# Altium schematic sheet PCIe Slots.SchDoc
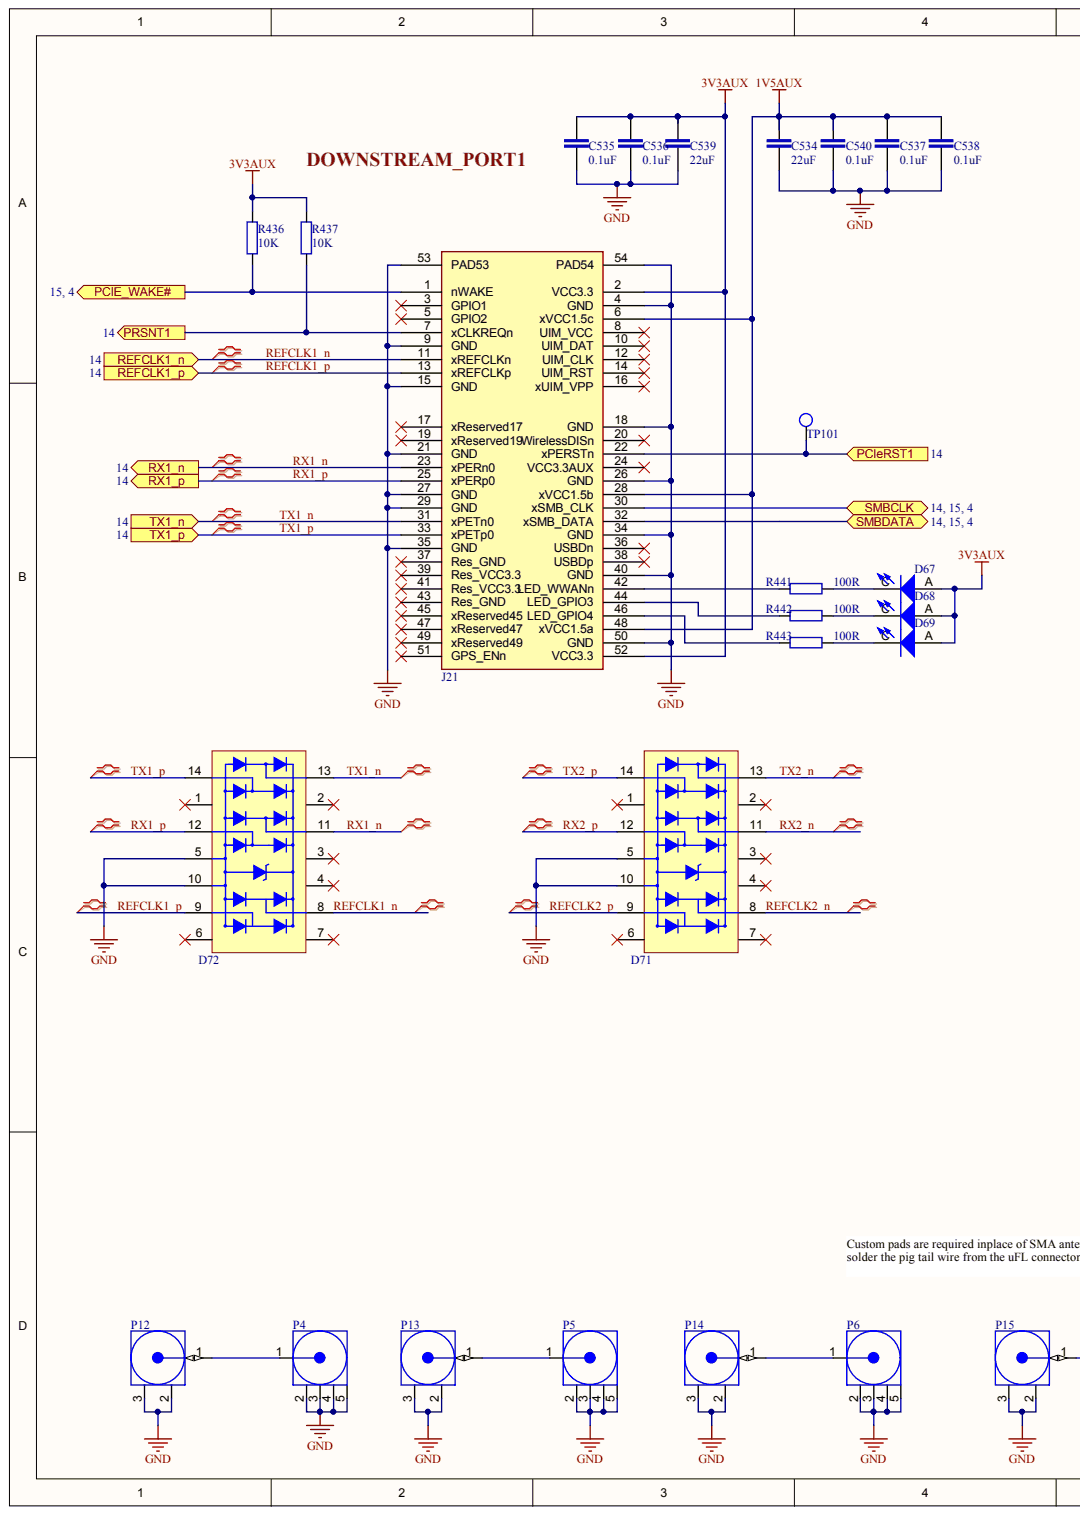
[diagram p0: PCIe Slots.SchDoc, left]
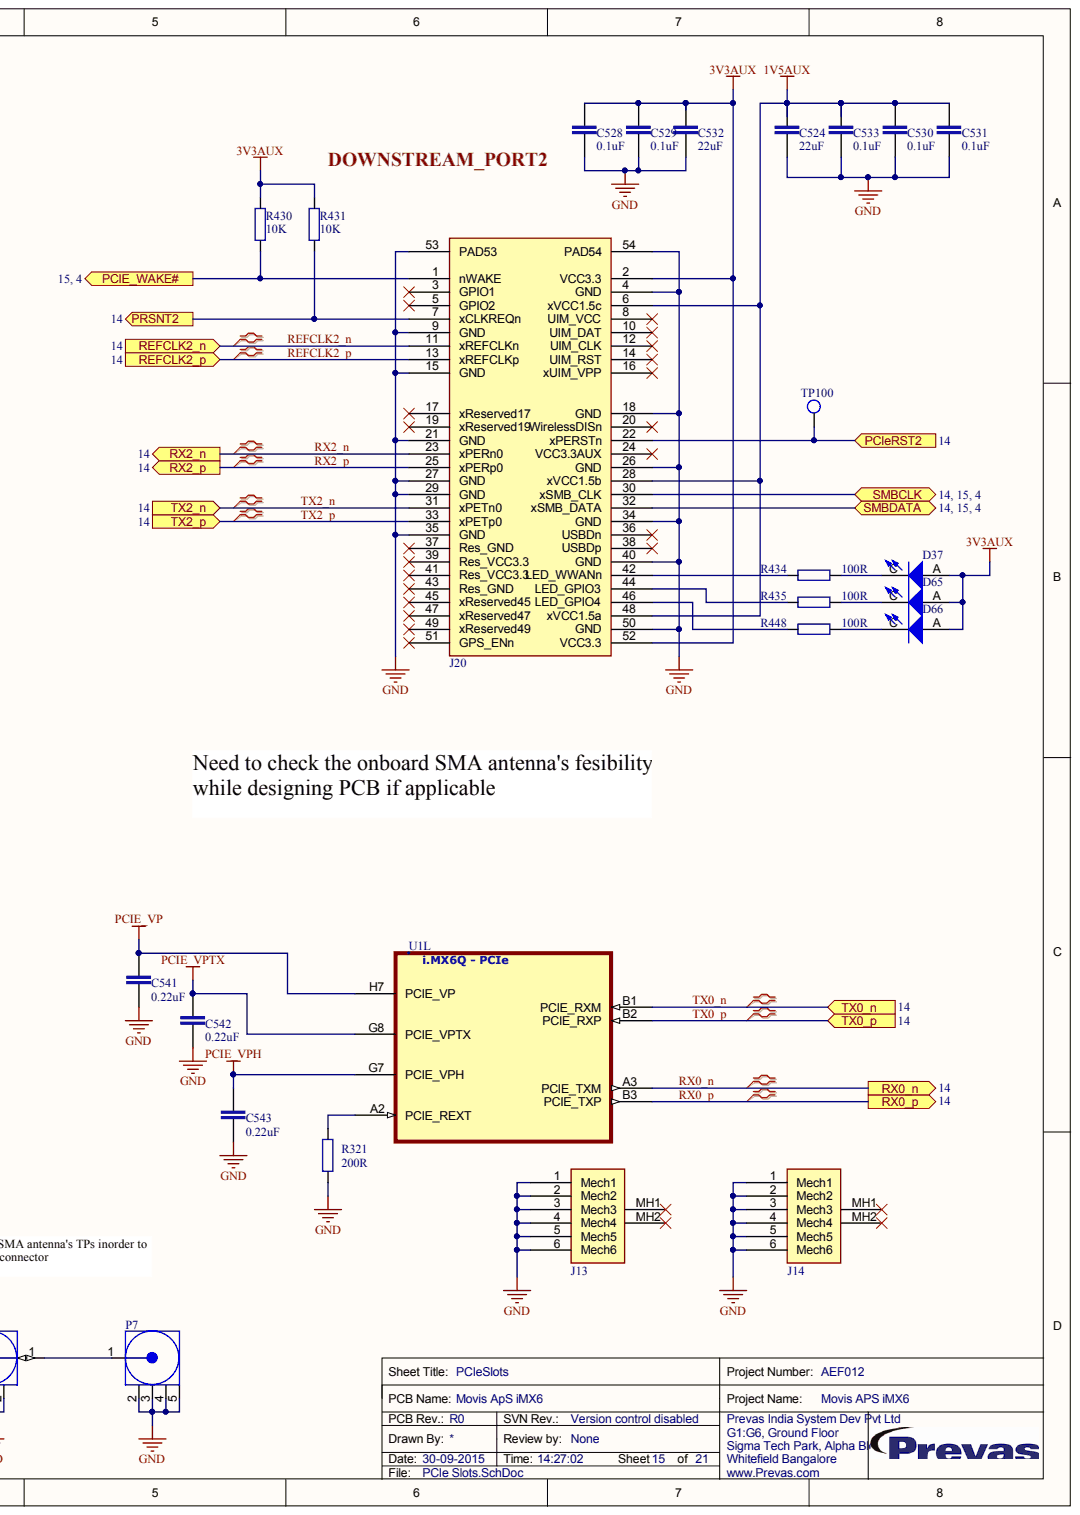
[diagram p1: PCIe Slots.SchDoc, right]

## Parts
C524  22uF  [CAPC3216X180N]
C528  0.1uF  [CAPC1005X55N]
C529  0.1uF  [CAPC1005X55N]
C530  0.1uF  [CAPC1005X55N]
C531  0.1uF  [CAPC1005X55N]
C532  22uF  [CAPC3216X180N]
C533  0.1uF  [CAPC1005X55N]
C534  22uF  [CAPC3216X180N]
C535  0.1uF  [CAPC1005X55N]
C536  0.1uF  [CAPC1005X55N]
C537  0.1uF  [CAPC1005X55N]
C538  0.1uF  [CAPC1005X55N]
C539  22uF  [CAPC3216X180N]
C540  0.1uF  [CAPC1005X55N]
C541  0.22uF  [CAPC1005X55N]
C542  0.22uF  [CAPC1005X55N]
C543  0.22uF  [CAPC1005X55N]
D37  elec_value  [LED_LTT64G]  (value not drawn)
D65  elec_value  [LED_LTT64G]  (value not drawn)
D66  elec_value  [LED_LTT64G]  (value not drawn)
D67  elec_value  [LED_LTT64G]  (value not drawn)
D68  elec_value  [LED_LTT64G]  (value not drawn)
D69  elec_value  [LED_LTT64G]  (value not drawn)
D71  TPD6E05U06  [SON50P135X350X55-14N]  (value not drawn)
D72  TPD6E05U06  [SON50P135X350X55-14N]  (value not drawn)
J13  Mini Card Latch  [CON_MINI_PCI_MM60-EZH039-B5-R850]  (value not drawn)
J14  Mini Card Latch  [CON_MINI_PCI_MM60-EZH039-B5-R850]  (value not drawn)
J20  MiniPcie_conn_52  [MINICARD_CON_52_PIN]  (value not drawn)
J21  MiniPcie_conn_52  [MINICARD_CON_52_PIN]  (value not drawn)
P4  638817-9  [CON_FAKRA_JACK_638817]  (value not drawn)
P5  638817-9  [CON_FAKRA_JACK_638817]  (value not drawn)
P6  638817-9  [CON_FAKRA_JACK_638817]  (value not drawn)
P7  638817-9  [CON_FAKRA_JACK_638817]  (value not drawn)
P12  COAX_2GND  [U.FL-R-SMT-1]  (value not drawn)
P13  COAX_2GND  [U.FL-R-SMT-1]  (value not drawn)
P14  COAX_2GND  [U.FL-R-SMT-1]  (value not drawn)
P15  COAX_2GND  [U.FL-R-SMT-1]  (value not drawn)
R321  200R  [RESC1608X55N]
R430  10K  [RESC1608X55N]
R431  10K  [RESC1608X55N]
R434  100R  [RESC1608X55N]
R435  100R  [RESC1608X55N]
R436  10K  [RESC1608X55N]
R437  10K  [RESC1608X55N]
R441  100R  [RESC1608X55N]
R442  100R  [RESC1608X55N]
R443  100R  [RESC1608X55N]
R448  100R  [RESC1608X55N]
TP100  Testpoint  [TP_SMD]  (value not drawn)  (no BOM)
TP101  Testpoint  [TP_SMD]  (value not drawn)  (no BOM)
U1  MCIMX6Q6AVT10AC  [BGA624C80P25X25_2100X2100X160]  (value not drawn)  (part L)

## Ports
PCIE_WAKE#, PCIERST1, PCIERST2, PRSNT1, PRSNT2, REFCLK1_N, REFCLK1_P, REFCLK2_N, REFCLK2_P, RX0_N, RX0_P, RX1_N, RX1_P, RX2_N, RX2_P, SMBCLK, SMBDATA, TX0_N, TX0_P, TX1_N, TX1_P, TX2_N, TX2_P

## Nets
1V5AUX: C524.2 C530.2 C531.2 C533.2 C534.2 C537.2 C538.2 C540.2 J20.6(xVCC1.5c) J20.28(xVCC1.5b) J20.48(xVCC1.5a) J21.6(xVCC1.5c) J21.28(xVCC1.5b) J21.48(xVCC1.5a)
3V3AUX: C528.2 C529.2 C532.2 C535.2 C536.2 C539.2 D37.A D65.A D66.A D67.A D68.A D69.A J20.2(VCC3.3) J20.52(VCC3.3) J21.2(VCC3.3) J21.52(VCC3.3) R430.2 R431.2 R436.2 R437.2
GND: C524.1 C528.1 C529.1 C530.1 C531.1 C532.1 C533.1 C534.1 C535.1 C536.1 C537.1 C538.1 C539.1 C540.1 C541.1 C542.1 C543.1 D71.5 D71.10 D72.5 D72.10 J13.1(Mech1) J13.2(Mech2) J13.3 J13.4 J13.5(Mech5) J13.6(Mech6) J14.1(Mech1) J14.2(Mech2) J14.3 J14.4 J14.5(Mech5) J14.6(Mech6) J20.4(GND) J20.9(GND) J20.15(GND) J20.18(GND) J20.21(GND) J20.26(GND) J20.27(GND) J20.29(GND) J20.34(GND) J20.35(GND) J20.40(GND) J20.50(GND) J20.53(PAD53) J20.54(PAD54) J21.4(GND) J21.9(GND) J21.15(GND) J21.18(GND) J21.21(GND) J21.26(GND) J21.27(GND) J21.29(GND) J21.34(GND) J21.35(GND) J21.40(GND) J21.50(GND) J21.53(PAD53) J21.54(PAD54) P4.2 P4.3 P4.4 P4.5 P5.2 P5.3 P5.4 P5.5 P6.2 P6.3 P6.4 P6.5 P7.2 P7.3 P7.4 P7.5 P12.2 P12.3 P13.2 P13.3 P14.2 P14.3 P15.2 P15.3 R321.1
PCIE_VP: C541.2 U1.H7(PCIE_VP)
PCIE_VPH: C543.2 U1.G7(PCIE_VPH)
PCIE_VPTX: C542.2 U1.G8(PCIE_VPTX)
PCIE_WAKE#: J20.1(nWAKE) J21.1(nWAKE) R430.1 R436.1 ; port PCIE_WAKE#
PRSNT1: J21.7(xCLKREQn) R437.1 ; port PRSNT1
PRSNT2: J20.7(xCLKREQn) R431.1 ; port PRSNT2
REFCLK1_N: D72.8 J21.11(xREFCLKn) ; port REFCLK1_N
REFCLK1_P: D72.9 J21.13(xREFCLKp) ; port REFCLK1_P
REFCLK2_N: D71.8 J20.11(xREFCLKn) ; port REFCLK2_N
REFCLK2_P: D71.9 J20.13(xREFCLKp) ; port REFCLK2_P
RX0_N: U1.A3(PCIE_TXM) ; port RX0_N
RX0_P: U1.B3(PCIE_TXP) ; port RX0_P
RX1_N: D72.11 J21.23(xPERn0) ; port RX1_N
RX1_P: D72.12 J21.25(xPERp0) ; port RX1_P
RX2_N: D71.11 J20.23(xPERn0) ; port RX2_N
RX2_P: D71.12 J20.25(xPERp0) ; port RX2_P
SMBCLK: J20.30(xSMB_CLK) J21.30(xSMB_CLK) ; port SMBCLK
SMBDATA: J20.32(xSMB_DATA) J21.32(xSMB_DATA) ; port SMBDATA
TX0_N: U1.B1(PCIE_RXM) ; port TX0_N
TX0_P: U1.B2(PCIE_RXP) ; port TX0_P
TX1_N: D72.13 J21.31(xPETn0) ; port TX1_N
TX1_P: D72.14 J21.33(xPETp0) ; port TX1_P
TX2_N: D71.13 J20.31(xPETn0) ; port TX2_N
TX2_P: D71.14 J20.33(xPETp0) ; port TX2_P
NetD37_C: D37.C R434.2
NetD65_C: D65.C R435.2
NetD66_C: D66.C R448.2
NetD67_C: D67.C R441.2
NetD68_C: D68.C R442.2
NetD69_C: D69.C R443.2
NetJ20_22: J20.22(xPERSTn) TP100.1 ; port PCIERST2
NetJ20_42: J20.42(LED_WWANn) R434.1
NetJ20_44: J20.44(LED_GPIO3) R435.1
NetJ20_46: J20.46(LED_GPIO4) R448.1
NetJ21_22: J21.22(xPERSTn) TP101.1 ; port PCIERST1
NetJ21_42: J21.42(LED_WWANn) R441.1
NetJ21_44: J21.44(LED_GPIO3) R442.1
NetJ21_46: J21.46(LED_GPIO4) R443.1
NetP4_1: P4.1 P12.1
NetP5_1: P5.1 P13.1
NetP6_1: P6.1 P14.1
NetP7_1: P7.1 P15.1
NetR321_2: R321.2 U1.A2(PCIE_REXT)

Not connected: D71 1 2 3 4 6 7; D72 1 2 3 4 6 7; J13 MH1(Mech3) MH2(Mech4); J14 MH1(Mech3) MH2(Mech4); J20 3(GPIO1) 5(GPIO2) 8(UIM_VCC) 10(UIM_DAT) 12(UIM_CLK) 14(UIM_RST) 16(xUIM_VPP) 17(xReserved17) 19(xReserved19) 20(WirelessDISn) 24(VCC3.3AUX) 36(USBDn) 37(Res_GND) 38(USBDp) 39(Res_VCC3.3) 41(Res_VCC3.3) 43(Res_GND) 45(xReserved45) 47(xReserved47) 49(xReserved49) 51(GPS_ENn); J21 3(GPIO1) 5(GPIO2) 8(UIM_VCC) 10(UIM_DAT) 12(UIM_CLK) 14(UIM_RST) 16(xUIM_VPP) 17(xReserved17) 19(xReserved19) 20(WirelessDISn) 24(VCC3.3AUX) 36(USBDn) 37(Res_GND) 38(USBDp) 39(Res_VCC3.3) 41(Res_VCC3.3) 43(Res_GND) 45(xReserved45) 47(xReserved47) 49(xReserved49) 51(GPS_ENn)

## Library data of the parts
C524 C532 C534 C539 = INNISI-77: CAP CER 22UF 25V 10% X5R 1206; ref_part_type: /capacitor/non_polarized/ceramic/; source_manufacturer_1: Murata Electronics; source_manufacturer_1_pn: GRM31CR61E226KE15L; cae_altium_pcb_status: Prototype; Database Table Name: altium_default; db_rev: 7573; elec_temp_range: -55 to +85 C; phy_height: 1.8mm; phy_mounting: SMD; phy_packaging_type: 1206; ref_datasheet: INNISI-74; ref_keyword: Multilayer chip capacitor; cae_altium_sch_status: Prototype; Component Kind: Standard; ComponentLink1Description: Datasheet; ComponentLink1URL: https://cop201.prevas.dk/viewvc/datasheet/INNISI-74.pdf?root=hw_components&view=co; db_created: 2015-08-03 04:56:21.0; db_last_updated: 03-08-2015 04:56:22; db_local_id: INNISI-77; db_status: Prototype; elec_tolerance: 10%; elec_value: 22uF; elec_voltage_rating: 25V; phy_material: X5R; Library Name: altium_mysql.dblib
C528-C531 C533 C535-C538 C540 = INNISI-73: CAP CER 0.1UF 50V 10% X5R 0402; ref_part_type: /capacitor/non_polarized/ceramic/; source_manufacturer_1: TDK Corporation; source_manufacturer_1_pn: C1005X5R1H104K050BB; cae_altium_pcb_status: Prototype; Database Table Name: altium_default; db_rev: 7569; elec_temp_range: -55 to +85 C; phy_height: 0.55mm; phy_mounting: SMD; phy_packaging_type: 0402; ref_datasheet: INNISI-71; cae_altium_sch_status: Prototype; Component Kind: Standard; ComponentLink1Description: Datasheet; ComponentLink1URL: https://cop201.prevas.dk/viewvc/datasheet/INNISI-71.pdf?root=hw_components&view=co; db_created: 2015-08-03 03:34:59.0; db_last_updated: 03-08-2015 03:35:01; db_local_id: INNISI-73; db_status: Prototype; elec_tolerance: ±10%; elec_value: 0.1uF; elec_voltage_rating: 50V; phy_material: X5R; ref_keyword: CAP CER 0.1UF 50V 10% X5R 0402; Library Name: altium_mysql.dblib
C541-C543 = innisi-76: CAP CER 0.22UF 16V 10% X7R 0402; ref_part_type: /capacitor/non_polarized/ceramic/; source_manufacturer_1: Murata; source_manufacturer_1_pn: GRM155R71C224KA12D; cae_altium_pcb_status: Prototype; Database Table Name: altium_default; db_rev: 7572; elec_temp_range: -55 to +125C; phy_height: 0.55mm; phy_mounting: SMD; phy_packaging_type: 0402; ref_datasheet: INNISI-73; ref_keyword: Ceramic capacitor X7R 0402; cae_altium_sch_status: Prototype; Component Kind: Standard; ComponentLink1Description: Datasheet; ComponentLink1URL: https://cop201.prevas.dk/viewvc/datasheet/INNISI-73.pdf?root=hw_components&view=co; db_created: 2015-08-03 04:28:56.0; db_last_updated: 03-08-2015 04:28:58; db_local_id: INNISI-76; db_status: Prototype; elec_tolerance: 10%; elec_value: 0.22uF; elec_voltage_rating: 16V; phy_material: X7R; Library Name: altium_mysql.dblib
D37 D65-D69 = INRAAN-158: Standard LEDs - SMD True Green; ref_part_type: /optical/emmision/diode/; source_manufacturer_1: OSRAM Opto Semiconductors; source_manufacturer_1_pn: LT T64G-DAFA-29; cae_altium_pcb_status: Prototype; Database Table Name: altium_default; db_rev: 7053; elec_temp_range: -40C to 100C; phy_height: 3.8mm; ref_datasheet: INRAAN-159; cae_altium_sch_status: Prototype; Component Kind: Standard; ComponentLink1Description: Datasheet; ComponentLink1URL: https://cop201.prevas.dk/viewvc/datasheet/INRAAN-159.pdf?root=hw_components&view=co; db_created: 2014-07-17 08:30:45.0; db_last_updated: 20-02-2015 11:04:44; db_local_id: INRAAN-158; db_status: Prototype; phy_mounting: SMD; ref_keyword: Standard LEDs - SMD; Library Name: altium_mysql.dblib
D71 D72 = INRAAN-238: ESD Suppressors 6-CH lo cap IEC ESD protect diodes; ref_part_type: /filter/esd/; source_manufacturer_1: Texas Instruments; cae_altium_pcb_status: Prototype; Database Table Name: altium_default; db_rev: 6943; elec_temp_range: -40C to 125C; phy_height: 0.55mm; phy_mounting: SMD; phy_packaging_type: USON-14; ref_datasheet: INRAAN-223; ref_keyword: ESD Protection Device; cae_altium_sch_status: Prototype; Component Kind: Standard; ComponentLink1Description: Datasheet; ComponentLink1URL: https://cop201.prevas.dk/viewvc/datasheet/INRAAN-223.pdf?root=hw_components&view=co; db_created: 2014-12-02 09:03:26.0; db_last_updated: 02-12-2014 09:03:28; db_local_id: INRAAN-238; db_status: Prototype; elec_value: TPD6E05U06; source_manufacturer_1_pn: TPD6E05U06; Library Name: altium_mysql.dblib
J13 J14 = (no symbol name): CONN MINI EXPRESS CARD LATCH SMD; ref_part_type: /connector/6 pins/; source_manufacturer_1: JAE Electronics; cae_altium_pcb_status: Prototype; db_local_id: INNISI-35; db_rev: 7428; elec_value: MM60-EZH039-B5-R850; phy_height: 3.9mm; ref_keyword: PCI Connectors Latch; cae_altium_sch_status: Prototype; Component Kind: Standard; ComponentLink1Description: Datasheet; ComponentLink1URL: https://cop201.prevas.dk/viewvc/datasheet/INNISI-35.pdf?root=hw_components&view=co; db_created: 2015-06-05 10:07:00.0; db_last_updated: 09-06-2015 10:40:34; db_status: Prototype; phy_mounting: SMD; ref_datasheet: INNISI-35; source_manufacturer_1_pn: MM60-EZH039-B5-R850; Library Name: Movis-main-card.SCHLIB
J20 J21 = INNISI-20: PCI Express / PCI Connectors mSATA/mini PCI-E 4H Type I G/F; Manufacturer: TE Connectivity; ref_part_type: /connector/; cae_altium_pcb_status: Prototype; Database Table Name: altium_default; db_rev: 7783; elec_value: 2041119-1; elec_voltage_rating: 1.5 V; phy_mounting: SMD; ref_keyword: miniPCIe 52 pin connector; cae_altium_sch_status: Prototype; Component Kind: Standard; ComponentLink1Description: Datasheet; ComponentLink1URL: https://cop201.prevas.dk/viewvc/datasheet/INNISI-20.pdf?root=hw_components&view=co; db_created: 2015-06-01 10:07:19.0; db_last_updated: 24-08-2015 06:41:20; db_local_id: INNISI-20; db_status: Prototype; ref_datasheet: INNISI-20; source_manufacturer_1: TE Connectivity; source_manufacturer_1_pn: 2041119-1; Supplier 1: Digi-Key; Supplier 2: Mouser; Supplier Part Number 1: A108265TR-ND; Supplier Part Number 2: 571-2041119-1; Library Name: altium_mysql.dblib
P4-P7 = INNISI-61: D/C R/A PCB FAKRA SMB ASY,KEYI; ref_part_type: /connector/; source_manufacturer_1: TE Connectivity; cae_altium_pcb_status: Prototype; Database Table Name: altium_default; db_rev: 7952; elec_current_rating: 1A; elec_frequency_rating: 0 – 3000MHz; elec_temp_range: -40°C to + 85?°C; phy_height: 10.75?mm; phy_mounting: Leaded; ref_datasheet: INNISI-59; ref_keyword: RF Connectors; cae_altium_sch_status: Prototype; Component Kind: Standard; ComponentLink1Description: Datasheet; ComponentLink1URL: https://cop201.prevas.dk/viewvc/datasheet/INNISI-59.pdf?root=hw_components&view=co; db_created: 2015-06-17 07:10:25.0; db_last_updated: 25-09-2015 05:38:56; db_local_id: INNISI-61; db_status: Prototype; elec_value: 638817-9; source_manufacturer_1_pn: 638817-9; Library Name: altium_mysql.dblib
P12-P15 = INNISI-66: Ultra Small Surface Mount Coaxial Connectors; ref_part_type: /connector/; source_manufacturer_1: Hirose Electric; source_manufacturer_1_pn: U.FL-R-SMT-1(01); cae_altium_pcb_status: Prototype; Database Table Name: altium_default; db_rev: 7499; elec_current_rating: 10mA; elec_temp_range: -40C - +90C; phy_mounting: SMD; ref_datasheet: INNISI-65; ref_keyword: U.FL connectors; cae_altium_sch_status: Prototype; Component Kind: Standard; ComponentLink1Description: Datasheet; ComponentLink1URL: https://cop201.prevas.dk/viewvc/datasheet/INNISI-65.pdf?root=hw_components&view=co; db_created: 2015-07-06 02:54:10.0; db_last_updated: 06-07-2015 02:54:11; db_local_id: INNISI-66; db_status: Prototype; Library Name: altium_mysql.dblib
R321 = JANI-41: ref_part_type: /resistor/fixed/; source_manufacturer_1: Multicomp; source_manufacturer_1_pn: MC 0.063W 0603 1% 200R; cae_altium_pcb_status: Prototype; Database Table Name: altium_default; db_rev: 4871; elec_current_rating: 1A; elec_power_rating: 0.063mW; elec_temp_range: -55°C to +155°C; elec_tolerance: 1%; elec_voltage_rating: 50V; phy_height: 0.45mm; phy_material: Thick film; phy_mounting: SMD; phy_packaging_type: 0603; ref_datasheet: JANI-23; ref_keyword: chip resistor; cae_altium_sch_status: Prototype; Component Kind: Standard; ComponentLink1Description: Datasheet; ComponentLink1URL: https://cop201.prevas.dk/viewvc/datasheet/JANI-23.pdf?root=hw_components&view=co; db_created: 2012-07-03 12:02:10.0; db_last_updated: 03-07-2012 12:02:24 PM; db_local_id: JANI-41; db_status: Prototype; elec_value: 200R; Library Name: altium_mysql.dblib
R430 R431 R436 R437 = INVIHA-3: RES SMD 10K OHM 1% 1/10W 0603; ref_part_type: /resistor/fixed/; source_manufacturer_1: Yageo; source_manufacturer_1_pn: RC0603FR-0710KL; cae_altium_pcb_status: Prototype; Database Table Name: altium_default; db_rev: 7575; elec_power_rating: 0.1W; elec_temp_range: -55 to +155° C; phy_height: 0.55mm; phy_packaging_type: 0603; ref_datasheet: INVIHA-2; ref_keyword: Chip Resistor; cae_altium_sch_status: Prototype; Component Kind: Standard; ComponentLink1Description: Datasheet; ComponentLink1URL: https://cop201.prevas.dk/viewvc/datasheet/INVIHA-2.pdf?root=hw_components&view=co; db_created: 2015-08-03 05:39:03.0; db_last_updated: 03-08-2015 5:39:05 AM; db_local_id: INVIHA-3; db_status: Prototype; elec_tolerance: 1%; elec_value: 10K; phy_mounting: SMD; Library Name: altium_mysql.dblib
R434 R435 R441-R443 R448 = INVIHA-6: RES SMD 100 OHM 1% 1/10W 0603; ref_part_type: /resistor/fixed/; source_manufacturer_1: Yageo; source_manufacturer_1_pn: RC0603FR-07100RL; cae_altium_pcb_status: Prototype; Database Table Name: altium_default; db_rev: 7583; elec_power_rating: 0.1W; elec_temp_range: -55 to +155° C; phy_height: 0.55mm; phy_packaging_type: 0603; ref_datasheet: INVIHA-2; ref_keyword: Chip Resistor; cae_altium_sch_status: Prototype; Component Kind: Standard; ComponentLink1Description: Datasheet; ComponentLink1URL: https://cop201.prevas.dk/viewvc/datasheet/INVIHA-2.pdf?root=hw_components&view=co; db_created: 2015-08-03 06:19:06.0; db_last_updated: 03-08-2015 6:19:07 AM; db_local_id: INVIHA-6; db_status: Prototype; elec_tolerance: 1%; elec_value: 100R; phy_mounting: SMD; Library Name: altium_mysql.dblib
TP100 TP101 = JEPE-114: Test Point SMD; ref_part_type: /pcb_item/; cae_altium_pcb_status: Prototype; Database Table Name: altium_default; db_rev: 3281; cae_altium_sch_status: Prototype; ComponentLink1Description: Datasheet; db_created: 2008-08-18 11:39:42; db_last_updated: 23-03-2011 14:14:06; db_local_id: JEPE-114; db_status: Prototype; elec_value: Test Point; phy_mounting: SMD; ref_keyword: Test  Point; Library Name: altium_mysql.dblib
U1 = INRAAN-5: i.MX 6Dual/6Quad; ref_part_type: /integrated/digital/processor/general/; AGILE_PN: 344-01218; CAD_APPROVED: Yes; cae_altium_pcb_status: Prototype; CLASS: IC; Database Table Name: altium_default; db_rev: 6247; elec_temp_range: -40 to +105; elec_voltage_rating: 1.5V; MFG_NAME01: FREESCALE SEMICONDUCTOR; phy_height: 1.85; phy_mounting: SMD; phy_packaging_type: FCPBGA96_624; ref_datasheet: INRAAN-6; cae_altium_sch_status: Prototype; Component Kind: Standard; ComponentLink1Description: Datasheet; ComponentLink1URL: https://cop201.prevas.dk/viewvc/datasheet/INRAAN-6.pdf?root=hw_components&view=co; db_created: 2013-10-21 12:25:57.0; db_last_updated: 27-12-2013 09:32:57; db_local_id: INRAAN-5; db_status: Prototype; elec_value: MCIMX6Q6AVT10AC; HEIGHT: 2.16MM; MFG_PN01: MCIMX6Q6AVT10AC; ref_keyword: i.MX 6Dual/6Quad; ROHS: Yes; source_manufacturer_1: Freescale Semiconductor; source_manufacturer_1_pn: MCIMX6Q6AVT10AC; Library Name: altium_mysql.dblib
